# Altium schematic sheet CAMERA.SchDoc(CAMERA)
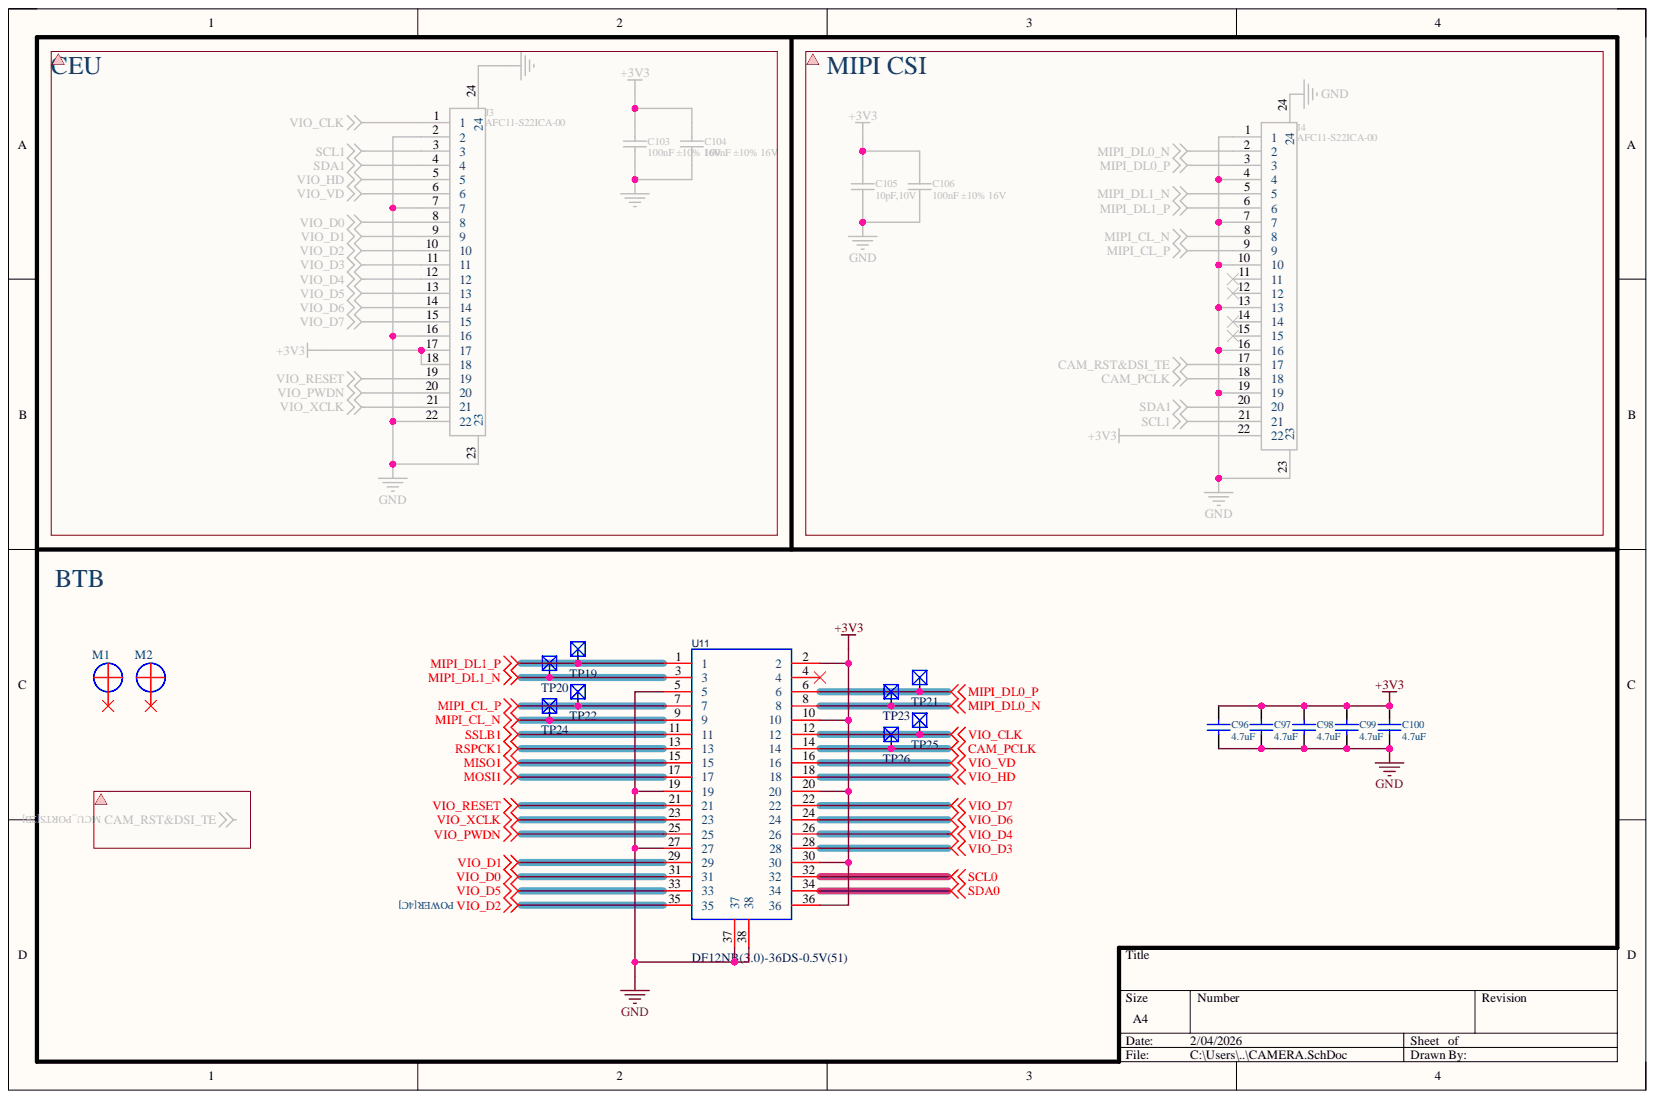
[diagram p0: CAMERA.SchDoc]

## Parts
C96  4.7uF  [C0603]
C97  4.7uF  [C0603]
C98  4.7uF  [C0603]
C99  4.7uF  [C0603]
C100  4.7uF  [C0603]
M1  SMD_NUT_M2x5.56x3+1.53mm  [SMD_NUT_M2x5.56x3+1.53mm]  (value not drawn)
M2  SMD_NUT_M2x5.56x3+1.53mm  [SMD_NUT_M2x5.56x3+1.53mm]  (value not drawn)
TP19  TEST POINT  [TP_M_0.5]  (value not drawn)
TP20  TEST POINT  [TP_M_0.5]  (value not drawn)
TP21  TEST POINT  [TP_M_0.5]  (value not drawn)
TP22  TEST POINT  [TP_M_0.5]  (value not drawn)
TP23  TEST POINT  [TP_M_0.5]  (value not drawn)
TP24  TEST POINT  [TP_M_0.5]  (value not drawn)
TP25  TEST POINT  [TP_M_0.5]  (value not drawn)
TP26  TEST POINT  [TP_M_0.5]  (value not drawn)
U11  DF12NB(3.0)-36DS-0.5V(51)  [CONN-SMD_36P-P0.50_HRS_DF12NB-3.0-36DP-0.5V]

## Nets
+3V3: C96.2 C97.2 C98.2 C99.2 C100.2 U11.2 U11.10 U11.20 U11.30 U11.36
CAM_PCLK: TP26.1 U11.14
GND: C96.1 C97.1 C98.1 C99.1 C100.1 U11.5 U11.19 U11.27 U11.37 U11.38
MIPI_CL_N: TP24.1 U11.9
MIPI_CL_P: TP22.1 U11.7
MIPI_DL0_N: TP23.1 U11.8
MIPI_DL0_P: TP21.1 U11.6
MIPI_DL1_N: TP20.1 U11.3
MIPI_DL1_P: TP19.1 U11.1
MISO1: U11.15
MOSI1: U11.17
RSPCK1: U11.13
SCL0: U11.32
SDA0: U11.34
SSLB1: U11.11
VIO_CLK: TP25.1 U11.12
VIO_D0: U11.31
VIO_D1: U11.29
VIO_D2: U11.35
VIO_D3: U11.28
VIO_D4: U11.26
VIO_D5: U11.33
VIO_D6: U11.24
VIO_D7: U11.22
VIO_HD: U11.18
VIO_PWDN: U11.25
VIO_RESET: U11.21
VIO_VD: U11.16
VIO_XCLK: U11.23

Not connected: M1 0; M2 0; U11 4

## Library data of the parts
C96-C100 = 0603 4.7uF (475) 10% 50V: 贴片电容; Component Kind: Standard; ComponentLink1Description: 供应商链接; ComponentLink1URL: https://item.szlcsc.com/1973.html; Library Name: RA8P1.SCHLIB; Package: 0603; Supplier: LC; SuppliersPartNumber: C19666
M1 M2 = SMD_NUT_M2x5.56x3+1.53mm: 贴片螺母，外径5.56，内径M3，M2螺丝固定; Component Kind: Standard; Library Name: RA8P1.SCHLIB
TP19-TP26 = TP: Component Kind: Standard; Library Name: RA8P1.SCHLIB
U11 = DF12NB(3.0)-36DS-0.5V(51): Component Kind: Standard; Library Name: RA8P1.SCHLIB; Symbol: DF12NB(3.0)-36DS-0.5V(51)
